# Altium schematic sheet Dual PMT Controller - Light Interlock.SchDoc
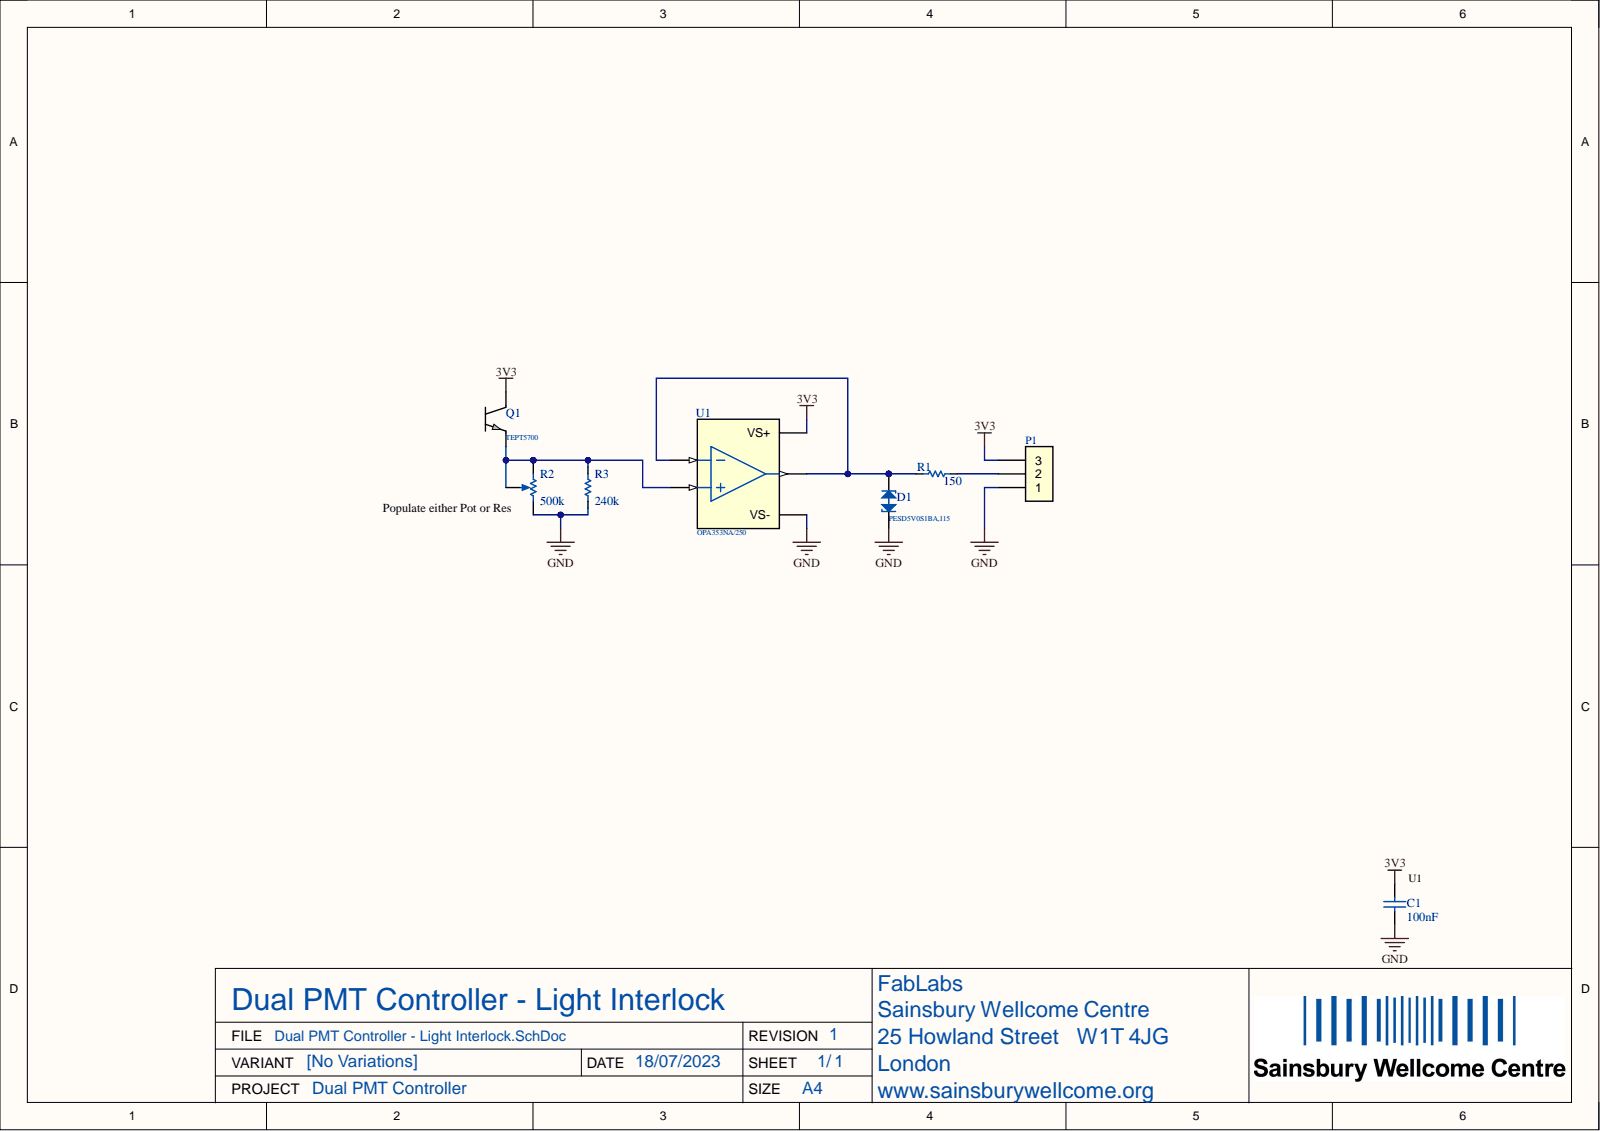
[diagram p0: Dual PMT Controller - Light Interlock.SchDoc]

## Parts
C1  value 100nF  Capacitance: 100nF  [FP-GRM188-0_1-e0_2_0_5-IPC_B]  (hidden comment: C0603-100nF-100V-10%-X7R)
D1  PESD5V0S1BA,115  [FP-SOD323-CA-IPC_B]
P1  Header-103  [Header-103]  (value not drawn)
Q1  TEPT5700  [LED_TEPT5700_VIS]
R1  value 150  [FP-CPF0603-IPC_B]  (hidden comment: R0603-150R-1/16W-0.1%)
R2  value 500k  Resistance: 500k  [SM-42TAxxx_NDC]  (hidden comment: SM-42TA504)
R3  value 240k  Resistance: 240k  [FP-CPF0603-IPC_B]  (hidden comment: R0603-240k-1/16W-0.1%)
U1  OPA353NA/250  [DBV0005A_N]

## Nets
3V3: C1.2 P1.3 Q1.1 U1.5(VS+)
GND: C1.1 D1.1 P1.1 R2.3 R3.1 U1.2(VS-)
NetD1_2: D1.2 R1.1 U1.1 U1.4
NetP1_2: P1.2 R1.2
NetQ1_2: Q1.2 R2.1 R2.2 R3.2 U1.3

## Library data of the parts
C1 = CMP-611-0027-19: 0.1µF ±10% 100V Ceramic Capacitor X7R 0603 (1608 Metric); Pick&PlaceFootprint: 0603_CAP; Capacitor Type: Ceramic; Case Code (Imperial): 0603; Case Code (Metric): 1608; Case/Package: 0603; Component Kind: Standard; Construction: Flat; Depth: 0.8mm; Dielectric: X7R; Height: 0.8mm; Lead Free: Lead Free; Length: 1.6mm; Material: Ceramic; Max Operating Temperature: 125°C; Min Operating Temperature: -55°C; Mount: Surface Mount; Package Quantity: 1; Packaging: Tape and Reel; Radiation Hardening: No; REACH SVHC: No SVHC; RoHS: Yes; RoHS Compliant: Yes; Series: GRM; Size: 0.8mm; Termination: SMD/SMT; Thickness: 0.035inch; Tolerance: 10%; Voltage: 100V; Voltage Rating: 100V; Voltage Rating (DC): 100V; Weight: 0.000222oz; Width: 0.8mm; Library Name: Sainsbury Wellcome Centre
D1 = CMP-820-0001-1: Trans Voltage Suppressor Diode, 130W, 5V V(RWM), Bidirectional, 1 Element, Silicon; Max Breakdown Voltage: 9.5V; Min Breakdown Voltage: 5.5V; Number of Elements: 1; Package Body Material: Plastic; Pick&PlaceFootprint: SOD323; Reference Standard: IEC-60134; Time @ Peak Reflow Temperature-Max (s): 30s; Component Kind: Standard; Diode Element Material: SILICON; Diode Type: TRANS VOLTAGE SUPPRESSOR; JESD-30 Code: R-PDSO-G2; JESD-609 Code: e3; Max Operating Temperature: 150°C; Moisture Sensitivity Level: 1; Mount: Surface Mount; Non-rep Peak Rev Power Dis-Max: 130W; Number of Pins: 2; Package Shape: Rectangular; Package Style: SMALL OUTLINEMeter; PartNumber: PESD5V0S1BA,115; Peak Reflow Temperature (Cel): 260°C; Polarity: Bidirectional; REACH SVHC: Yes; Rep Pk Reverse Voltage-Max: 5V; RoHS Compliant: Yes; Surface Mount: Yes; Technology: AVALANCHE; Terminal Finish: Tin; Terminal Form: Gull Wing; Terminal Position: DUAL; Library Name: Sainsbury Wellcome Centre
P1 = CMP-370-0045-1: 0.1" spaced holes to solder wires into; Component Kind: Standard; Mount: Surface Mount; PartNumber: Header-103; Pitch: 0.1"; Library Name: Sainsbury Wellcome Centre
Q1 = CMP-540-0000-1: Ambient Light Sensor Phototransistor Chip Silicon 570nm 2-Pin T-1 3/4; Collector Emitter Breakdown Voltage: 6V; Dark Current: 50nA; Lens Style: Flat; Max Collector Current: 20mA; Max Power Dissipation: 100mW; Number of Channels: 1; Operating Supply Voltage: 5V; Output Type: Analog; Polarity: NPN; Viewing Angle: 50°; Case/Package: Radial; Collector Emitter Voltage (VCEO): 6V; Component Kind: Standard; Height: 5mm; Lead Free: Lead Free; Length: 5.7mm; Max Operating Temperature: 85°C; Min Operating Temperature: -40°C; Mount: Through Hole; Number of Elements: 1; Number of Pins: 2; Package Quantity: 4000; Packaging: Bulk; Power Consumption: 100mW; Power Dissipation: 100mW; Radiation Hardening: No; REACH SVHC: Unknown; RoHS Compliant: Yes; Series: -; Wavelength: 570nm; Width: 5.7mm; Library Name: Sainsbury Wellcome Centre
R1 = CMP-633-0078-2: Surface Mount Resistor 150 Ohm 1/16 W 0.1% 0603 (1608 Metric); Max Power Dissipation: 62.5 mW; Number of Resistors: 1; Pick&PlaceFootprint: R0603; Power Rating: 62.5mW; Temperature Coefficient: 25ppm/°C; Voltage Rating: 50V; Case Code (Imperial): 0603; Case Code (Metric): 1608; Case/Package: 0603; Component Kind: Standard; Composition: Thin Film; Construction: Chip; ELV: Compliant; Height: 0.45mm; Length: 1.6mm; Max Operating Temperature: 155°C; Min Operating Temperature: -55°C; Mount: Surface Mount; Number of Pins: 2; Number of Terminations: 2; Packaging: Tape and Reel; REACH SVHC: Yes; Resistance: 150; Resistance Tolerance: 0.1%; Resistor Type: FIXED RESISTOR; RoHS: Yes; RoHS Compliant: Yes; Series: CPF; Size Code: 0603; Technology: THIN FILM; Termination: Wraparound; Tolerance: 0.1%; Voltage Rating (DC): 50V; Weight: 7.1E-05oz; Width: 0.8mm; Library Name: Sainsbury Wellcome Centre
R2 = CMP-632-0001-2: Trimmer, Cermet, 0.25W, 500k ohm, 300V, 10% +/-Tol, 100ppm/Cel, 11 Turn(s), 1918; Number of Turns: 11; Power Rating: 250mW; Case/Package: SM-42TA; Component Kind: Standard; Mount: SMD; PartNumber: SM-42TA504; Pick&PlaceFootprint: SM-42TA; RoHS: Yes; Tolerance: 10%; Voltage Rating: 300V; Library Name: Sainsbury Wellcome Centre
R3 = CMP-633-0073-14: Surface Mount Resistor 240k Ohm 1/16 W 0.1% 0603 (1608 Metric); Max Power Dissipation: 62.5 mW; Number of Resistors: 1; Pick&PlaceFootprint: R0603; Power Rating: 62.5mW; Temperature Coefficient: 25ppm/°C; Voltage Rating: 50V; Case Code (Imperial): 0603; Case Code (Metric): 1608; Case/Package: 0603; Component Kind: Standard; Composition: Thin Film; ELV: Compliant; Height: 0.45mm; Length: 1.6mm; Max Operating Temperature: 155°C; Min Operating Temperature: -55°C; Mount: Surface Mount; Number of Pins: 2; Number of Terminations: 2; Packaging: Tape and Reel; REACH SVHC: No SVHC; Resistance Tolerance: 0.1%; Resistor Type: Thin Film; RoHS: Yes; RoHS Compliant: Yes; Series: CPF; Size Code: 0603; Technology: Thin Film; Termination: Solder; Tolerance: 0.1%; Voltage Rating (DC): 50V; Weight: 7.1E-05oz; Width: 0.8mm; Library Name: Sainsbury Wellcome Centre
U1 = CMP-941-0005-3: Operational Amplifier, 1 Func, 8000uV Offset-Max, CMOS, PDSO5; Pick&PlaceFootprint: SOT-23-5; Component Kind: Standard; Component Name: OPA353NA/250; PartNumber: OPA353NA/250; Library Name: Sainsbury Wellcome Centre
